# Altium schematic sheet MCU_Signals.SchDoc(MCU_Signals)
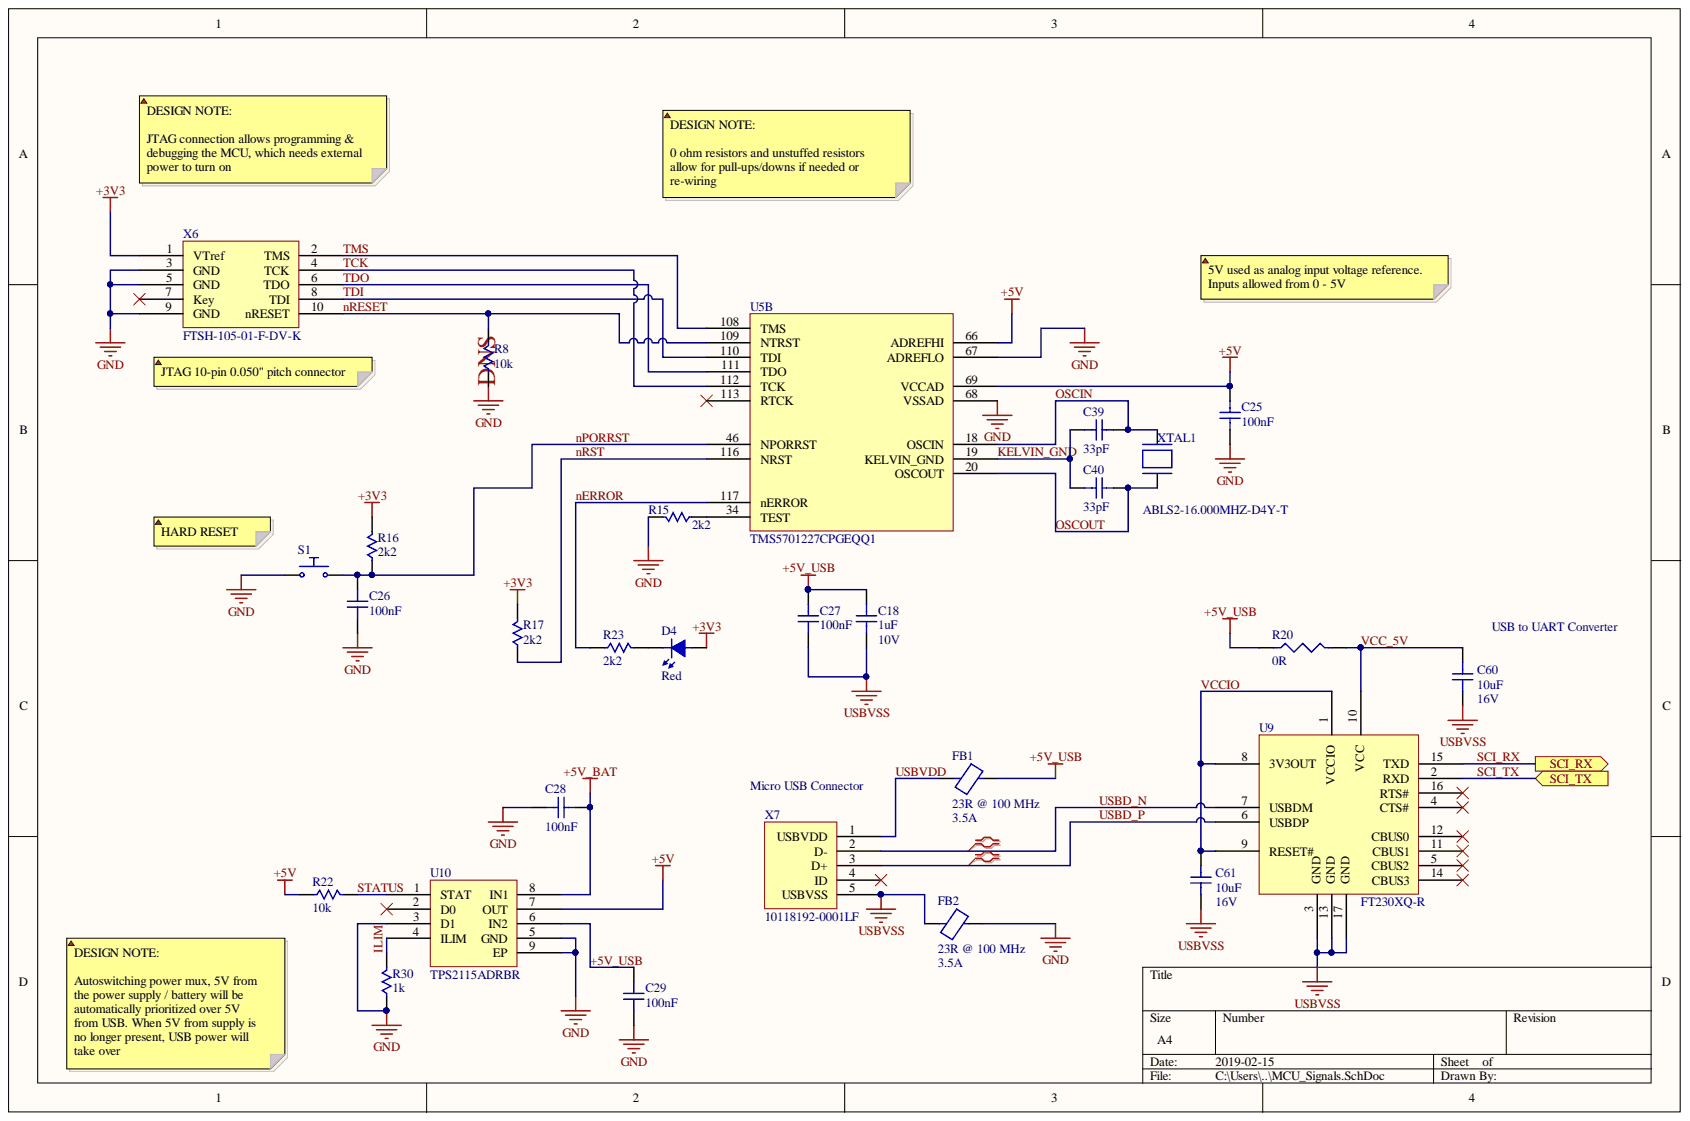
[diagram p0: MCU_Signals.SchDoc]

## Parts
C18  1uF  Voltage Rating (DC): 10V  [C0402]
C25  100nF  [C0402]
C26  100nF  [C0402]
C27  100nF  [C0402]
C28  100nF  [C0402]
C29  100nF  [C0402]
C39  33pF  [C0402]
C40  33pF  [C0402]
C60  10uF  Voltage Rating (DC): 16V  [C0805]
C61  10uF  Voltage Rating (DC): 16V  [C0805]
D4  Red  [LED_0603]
FB1  23R @ 100 MHz  Max DC Current: 3.5A  Impedance: 23R  [FB_1806]
FB2  23R @ 100 MHz  Max DC Current: 3.5A  Impedance: 23R  [FB_1806]
R8  10k  [R0402]
R15  2k2  [R0402]
R16  2k2  [R0402]
R17  2k2  [R0402]
R20  0R  [R0402]
R22  10k  [R0402]
R23  2k2  [R0402]
R30  1k  [R0402]
S1  PTS645SM43SMTR92LFS  [PUSH_BUTTON]  (value not drawn)
U5  TMS5701227CPGEQQ1  [LQFP-144]  (part B)
U9  FT230XQ-R  [QFN_EP-16]
U10  TPS2115ADRBR  [VSON-8]
X6  FTSH-105-01-F-DV-K  [JTAG_50mil_10pin]
X7  10118192-0001LF  [USB_MICRO]
XTAL1  ABLS2-16.000MHZ-D4Y-T  [XTAL]

## Ports
SCI_RX, SCI_TX

## Nets
+3V3: D4.1 R16.2 R17.2 X6.1(VTref)
+5V: C25.2 R22.1 U5.66(ADREFHI) U5.69(VCCAD) U10.7(OUT)
+5V_BAT: C28.1 U10.8(IN1)
GND: C25.1 C26.1 C28.2 C29.1 FB2.2 R8.1 R15.2 R30.1 S1.1 U5.67(ADREFLO) U5.68(VSSAD) U10.3(D1) U10.5(GND) U10.9(EP) X6.3(GND) X6.5(GND) X6.9(GND)
USBVSS: C18.1 C27.2 C60.1 C61.1 FB2.1 U9.3(GND) U9.13(GND) U9.17(GND) X7.5(USBVSS)
+5V_USB: C18.2 C27.1 C29.2 FB1.2 R20.1 U10.6(IN2)
ILIM: R30.2 U10.4(ILIM)
KELVIN_GND: C39.2 C40.2 U5.19(KELVIN_GND)
nERROR: R23.1 U5.117(nERROR)
nPORRST: C26.2 R16.1 S1.2 U5.46(NPORRST)
nRESET: R8.2 U5.109(NTRST) X6.10(nRESET)
nRST: R17.1 U5.116(NRST)
OSCIN: C39.1 U5.18(OSCIN) XTAL1.1
OSCOUT: C40.1 U5.20(OSCOUT) XTAL1.2
SCI_RX: U9.15(TXD) ; port SCI_RX
SCI_TX: U9.2(RXD) ; port SCI_TX
STATUS: R22.2 U10.1(STAT)
TCK: U5.112(TCK) X6.4(TCK)
TDI: U5.110(TDI) X6.8(TDI)
TDO: U5.111(TDO) X6.6(TDO)
TMS: U5.108(TMS) X6.2(TMS)
USBD_N: U9.7(USBDM) X7.2(D-)
USBD_P: U9.6(USBDP) X7.3(D+)
USBVDD: FB1.1 X7.1(USBVDD)
VCC_5V: C60.2 R20.2 U9.10(VCC)
VCCIO: C61.2 U9.1(VCCIO) U9.8(3V3OUT) U9.9(RESET#)
NetD4_2: D4.2 R23.2
NetR15_1: R15.1 U5.34(TEST)

Not connected: U5 113(RTCK); U9 4(CTS#) 5(CBUS2) 11(CBUS1) 12(CBUS0) 14(CBUS3) 16(RTS#); U10 2(D0); X6 7(Key); X7 4(ID)

## Library data of the parts
C18 = CL05A105MP5NNNC: CAP MLCC 1uF 10V 0402 X5R 20% SMD 0402 85C Paper T/R; Manufacturer: Samsung; Width: 0.02inch; Voltage Rating: 10V; Tolerance: 20%; Thickness: 0.022inch; Supplier Part Number 1: 1276-1448-1-ND; Supplier 1: Digi-Key; Stock 1: 100000; Radiation Hardening: No; Pricing 1: 1=0.1, 10=0.031, 100=0.014, 500=0.01, 1000=0.0079, 2500=0.0072, 5000=0.0066 (USD); Packaging: Tape and Reel; Package Quantity: 1; Mount: Surface Mount; Min Operating Temperature: -55°C; Max Operating Temperature: 85°C; Manufacturer Part Number: CL05A105MP5NNNC; Length: 1mm; Lead Free: Lead Free; Height: 0.5mm; Dielectric: X5R; Depth: 0.5mm; ComponentLink1URL: https://media.digikey.com/pdf/Data%20Sheets/Samsung%20PDFs/CL_Series_MLCC_ds.pdf; ComponentLink1Description: https://media.digikey.com/pdf/Data%20Sheets/Samsung%20PDFs/CL_Series_MLCC_ds.pdf; Component Kind: Standard; Case Code (Metric): 1005; Case Code (Imperial): 0402; Case/Package: 0402; Capacitance: 1uF; Library Name: PHANTOM_LIBRARY.SchLib
C25-C29 = CL05A104MP5NNNC: CAP MLCC 0.1uF 10V X5R 0402 20% SMD 0402 85C Paper T/R; Manufacturer: Samsung; Width: 0.02inch; Voltage Rating (DC): 10V; Voltage Rating: 10V; Tolerance: 20%; Thickness: 0.022inch; Supplier Part Number 1: 1276-1443-1-ND; Supplier 1: Digi-Key; Stock 1: 100000; Radiation Hardening: No; Pricing 1: 1=0.1, 10=0.024, 100=0.0106, 500=0.0076, 1000=0.006, 2500=0.0054, 5000=0.005 (USD); Packaging: Tape and Reel; Mount: Surface Mount; Min Operating Temperature: -55°C; Max Operating Temperature: 85°C; Manufacturer Part Number: CL05A104MP5NNNC; Length: 1mm; Lead Free: Lead Free; Height: 0.5mm; Dielectric: X5R; Depth: 0.5mm; ComponentLink1URL: https://media.digikey.com/pdf/Data%20Sheets/Samsung%20PDFs/CL_Series_MLCC_ds.pdf; ComponentLink1Description: https://media.digikey.com/pdf/Data%20Sheets/Samsung%20PDFs/CL_Series_MLCC_ds.pdf; Component Kind: Standard; Case Code (Metric): 1005; Case Code (Imperial): 0402; Case/Package: 0402; Capacitance: 100nF; Library Name: PHANTOM_LIBRARY.SchLib
C39 C40 = 885012005010: CAP MLCC 33pF 10V C0G, NP0 0402 (1005 Metric) 0.039" L x 0.020" W (1.00mm x 0.50mm); Manufacturer: Wurth Electronics; Tolerance: 5%; Width: 0.02inch; Thickness: 0.022inch; Supplier Part Number 1: 732-7428-1-ND; Supplier 1: Digi-Key; Stock 1: 4748; Pricing 1: 1=0.1, 10=0.015, 100=0.0132, 500=0.0116 (USD); Packaging: Tape and Reel; Mount: Surface Mount; Min Operating Temperature: -55°C; Max Operating Temperature: 125°C; Manufacturer Part Number: 885012005010; Length: 0.039inch; Component Kind: Standard; Case Code (Metric): 1005; Case Code (Imperial): 0402; Case/Package: 0402; Capacitance: 33pF; Library Name: PHANTOM_LIBRARY.SchLib
C60 C61 = CL21A106KOQNNNE: CAP CER 10uF 16V X5R 10% SMD 0805 85C T/R; Manufacturer: Samsung; Dielectric: X7R; Width: 0.049inch; Voltage Rating: 16V; Voltage: 16V; Tolerance: 10%; Thickness: 0.055inch; Supplier Part Number 1: 1276-1096-1-ND; Supplier 1: Digi-Key; Stock 1: 20000; Radiation Hardening: No; Pricing 1: 1=0.21, 10=0.148, 100=0.0698, 500=0.0489, 1000=0.0405 (USD); Packaging: Tape and Reel; Package Quantity: 1; Mount: Surface Mount; Min Operating Temperature: -55°C; Max Operating Temperature: 85°C; Manufacturer Part Number: CL21A106KOQNNNE; Library Name: ESC_SYMBOLS.SchLib; Length: 2mm; Lead Free: Lead Free; Height: 1.25mm; Depth: 1.25mm; ComponentLink3URL: https://datasheet.ciiva.com/15216/getdatasheetpartid-358435-15216234.pdf; ComponentLink3Description: https://datasheet.ciiva.com/15216/getdatasheetpartid-358435-15216234.pdf; ComponentLink2URL: https://datasheet.ciiva.com/16740/cl05b104kq5nnnc-16740697.pdf; ComponentLink2Description: https://datasheet.ciiva.com/16740/cl05b104kq5nnnc-16740697.pdf; ComponentLink1URL: https://datasheet.ciiva.com/25798/cl21a106koqnnne-25798133.pdf; ComponentLink1Description: https://datasheet.ciiva.com/25798/cl21a106koqnnne-25798133.pdf; Component Kind: Standard; Case Code (Metric): 2012; Case Code (Imperial): 0805; Case/Package: 0805; Capacitance: 10uF
D4 = 150060RS75000: LED RED CLEAR 0603 SMD 625 nm, 2 V, 30 mA, 250 mcd; Manufacturer: Wurth Electronics; Wavelength: 625nm; Viewing Angle: 140°; Test Current: 20mA; Luminous Intensity: 250mcd; Lens Style: Clear,Rectangular; Forward Voltage: 2V; Forward Current: 30mA; Width: 0.8mm; Supplier Part Number 1: 732-4978-1-ND; Supplier 1: Digi-Key; Stock 1: 273101; REACH SVHC: Unknown; Pricing 1: 1=0.14, 50=0.129, 100=0.11, 500=0.095 (USD); Packaging: Cut Tape; Package Quantity: 1; Mount: Surface Mount; Min Operating Temperature: -40°C; Max Operating Temperature: 85°C; Manufacturer Part Number: 150060RS75000; Length: 1.6mm; Height: 0.7mm; Dominant Wavelength: 625nm; ComponentLink2URL: http://www.produktinfo.conrad.com/datenblaetter/750000-774999/759428-da-01-en-CHIPLED_0603_W_KL_ROT_150060RS75000.pdf; ComponentLink2Description: http://www.produktinfo.conrad.com/datenblaetter/750000-774999/759428-da-01-en-CHIPLED_0603_W_KL_ROT_150060RS75000.pdf; ComponentLink1URL: https://katalog.we-online.de/led/datasheet/150060RS75000.pdf; ComponentLink1Description: https://katalog.we-online.de/led/datasheet/150060RS75000.pdf; Component Kind: Standard; Color: Red; Case Code (Metric): 1608; Case Code (Imperial): 0603; Case/Package: 0603; Library Name: PHANTOM_LIBRARY.SchLib
FB1 FB2 = FBMJ4516HL230NTV: FERRITE BEAD Ind Chip Bead Multi-Layer 23Ohm 30% 100MHz Ferrite 3.5A 1806 T/R; Manufacturer: Taiyo Yuden; Resistance: 180623R; Number of Lines: 1; DC Resistance (DCR): 14mR; Width: 1.6mm; Tolerance: 30%; Test Frequency: 100MHz; Termination: SMD/SMT; Supplier Part Number 1: 587-3857-1-ND; Supplier 1: Digi-Key; Stock 1: 1819; Pricing 1: 1=0.26, 10=0.202, 25=0.1676, 50=0.1444, 100=0.1299, 250=0.1097, 500=0.0982, 1000=0.0837 (USD); Packaging: Tape and Reel; Package Quantity: 2000; Mount: Surface Mount; Min Operating Temperature: -40°C; Max Operating Temperature: 125°C; Max Current Rating: 3.5A; Manufacturer Part Number: FBMJ4516HL230NTV; Length: 0.177inch; Height: 1.1mm; Depth: 1.6mm; Core Material: Ferrite; ComponentLink3URL: https://www.yuden.co.jp/ut/product/category/emisuppression/FBMJ4516HL230NTV_SS.pdf; ComponentLink3Description: https://www.yuden.co.jp/ut/product/category/emisuppression/FBMJ4516HL230NTV_SS.pdf; ComponentLink2URL: http://ds.yuden.co.jp/TYCOMPAS/ut/detail.do?productNo=FBMJ4516HL230NTV&fileName=FBMJ4516HL230NTV_SS&mode=specSheetDownload; ComponentLink2Description: http://ds.yuden.co.jp/TYCOMPAS/ut/detail.do?productNo=FBMJ4516HL230NTV&fileName=FBMJ4516HL230NTV_SS&mode=specSheetDownload; ComponentLink1URL: http://www.mouser.com/ds/2/396/chipbeads01_e-559265.pdf; ComponentLink1Description: http://www.mouser.com/ds/2/396/chipbeads01_e-559265.pdf; Component Kind: Standard; Case Code (Metric): 4516; Case Code (Imperial): 1806; Case/Package: 1806; Library Name: PHANTOM_LIBRARY.SchLib
R8 R22 = RC0402JR-0710KL: RES SMD 10K OHM 5% 1/16W 0402; Manufacturer: Yageo; Voltage Rating: 50V; Temperature Coefficient: 100ppm/°C; Resistance: 10kR; Power Rating: 62.5mW; Max Power Dissipation: 63mW; Width: 0.02inch; Tolerance: 5%; Termination: SMD/SMT; Supplier Part Number 1: 311-10KJRCT-ND; Supplier 1: Digi-Key; Stock 1: 47303622; REACH SVHC: Unknown; Pricing 1: 1=0.1, 10=0.018, 100=0.0073, 1000=0.0033, 2500=0.0029, 5000=0.0024 (USD); Packaging: Tape and Reel; Package Quantity: 1; Number of Terminations: 2; Min Operating Temperature: -55°C; Military Standard: Not; Max Operating Temperature: 155°C; Manufacturer Part Number: RC0402JR-0710KL; Length: 1mm; Lead Free: Lead Free; Height: 0.016inch; Features: Moisture Resistant; Depth: 0.5mm; Composition: Thick Film; Component Kind: Standard; Case Code (Metric): 1005; Case Code (Imperial): 0402; Case/Package: 0402; Library Name: PHANTOM_LIBRARY.SchLib
R15-R17 R23 = RC0402JR-072K2L: RES SMD 2.2K OHM 5% 1/16W 0402; Manufacturer: Yageo; Working Voltage: 50V; Temperature Coefficient: 100ppm/°C; Resistance: 2.2kR; Power Rating: 63mW; Width: 0.02inch; Weight: 2.3E-05oz; Voltage Rating: 50V; Tolerance: 5%; Termination: SMD/SMT; Supplier Part Number 1: 311-2.2KJRCT-ND; Supplier 1: Digi-Key; Stock 1: 7890813; REACH SVHC: Unknown; Pricing 1: 1=0.1, 10=0.018, 100=0.0073, 1000=0.0033, 2500=0.0029, 5000=0.0024 (USD); Packaging: Tape and Reel; Package Quantity: 1; Number of Terminations: 2; Min Operating Temperature: -55°C; Military Standard: Not; Max Power Dissipation: 63mW; Max Operating Temperature: 155°C; Manufacturer Part Number: RC0402JR-072K2L; Length: 1mm; Lead Free: Lead Free; Height: 0.016inch; Features: Moisture Resistant; Depth: 0.5mm; Composition: Thick Film; Component Kind: Standard; Case Code (Metric): 1005; Case Code (Imperial): 0402; Case/Package: 0402; Library Name: PHANTOM_LIBRARY.SchLib
R20 = RC0402JR-070RL: RES SMD 0 OHM 1/16W 0402; Manufacturer: Yageo; Voltage Rating: 50V; Tolerance: 5%; Temperature Coefficient: 200ppm/°C; Resistance: 0mR; Power Rating: 62.5mW; Max Power Dissipation: 63mW; Width: 0.02inch; Termination: SMD/SMT; Supplier Part Number 1: 311-0.0JRCT-ND; Supplier 1: Digi-Key; Stock 1: 44333412; REACH SVHC: Unknown; Radiation Hardening: No; Pricing 1: 1=0.1, 10=0.017, 25=0.0124, 50=0.0096, 100=0.007, 250=0.0054, 500=0.0043, 1000=0.0032, 5000=0.0023 (USD); Packaging: Tape and Reel; Package Quantity: 1; Number of Terminations: 2; Min Operating Temperature: -55°C; Military Standard: Not; Max Operating Temperature: 155°C; Manufacturer Part Number: RC0402JR-070RL; Library Name: ESC_SYMBOLS.SchLib; Length: 1mm; Lead Free: Lead Free; Height: 0.016inch; Features: Moisture Resistant; Depth: 0.5mm; Contact Plating: Matte,Tin; Composition: Thick Film; Component Kind: Standard; Case Code (Metric): 1005; Case Code (Imperial): 0402; Case/Package: 0402
R30 = RR0510P-102-D: RES SMD 1K OHM 0.5% 1/16W 0402; Manufacturer: Susumu; Voltage Rating (DC): 25V; Temperature Coefficient: 25ppm/°C; Resistance: 1kR; Power Rating: 63mW; Width: 0.02inch; Voltage Rating: 25V; Tolerance: 0.5%; Termination: SMD/SMT; Supplier Part Number 1: RR05P1.0KDCT-ND; Supplier 1: Digi-Key; Stock 1: 721157; REACH SVHC: Unknown; Pricing 1: 1=0.1, 10=0.074, 100=0.0285, 1000=0.0125, 2500=0.0108, 5000=0.0097 (USD); Packaging: Tape and Reel; Package Quantity: 1; Number of Terminations: 2; Number of Pins: 2; Min Operating Temperature: -55°C; Max Power Dissipation: 63mW; Max Operating Temperature: 125°C; Manufacturer Part Number: RR0510P-102-D; Length: 0.039inch; Lead Free: Lead Free; Height: 0.016inch; Composition: Thin Film; ComponentLink2URL: http://www.farnell.com/datasheets/1581132.pdf; ComponentLink2Description: http://www.farnell.com/datasheets/1581132.pdf; ComponentLink1URL: http://www.susumu.co.jp/common/pdf/n_catalog_partition05_en.pdf; ComponentLink1Description: http://www.susumu.co.jp/common/pdf/n_catalog_partition05_en.pdf; Component Kind: Standard; Case Code (Metric): 1005; Case Code (Imperial): 0402; Case/Package: 0402; Library Name: PHANTOM_LIBRARY.SchLib
S1 = PTS645SM43SMTR92LFS: SWITCH TACTILE SPST-NO 0.05A 12V; Manufacturer: ITT C&K; Throw Configuration: SPST; Switch Function: Off-Mom; Operating Force: 160gf; Mechanical Life: 100000Cycles; Max Current Rating: 50mA; Illumination Type: Non-Illuminated,Illuminated; Contact Resistance: 100mR; Actuator Material: Nylon,Polyamide; Actuator Length: 0.85mm; Voltage Rating (DC): 12V; Termination: Gull Wing; Supplier Part Number 1: CKN9112CT-ND; Supplier 1: Digi-Key; Stock 1: 188827; REACH SVHC: No SVHC; Pricing 1: 1=0.16, 10=0.157, 25=0.1516, 50=0.1462, 100=0.1381, 250=0.13, 500=0.1245 (USD); Packaging: Tape and Reel; Package Quantity: 1000; Orientation: Straight; Mount: Surface Mount; Min Operating Temperature: -20°C; Max Voltage Rating (DC): 12V; Max Operating Temperature: 60°C; Manufacturer Part Number: PTS645SM43SMTR92LFS; Length: 6mm; Lead Free: Lead Free; Depth: 9mm; Current Rating: 50mA; Contact Plating: Silver; Contact Material: Silver; ComponentLink5URL: https://datasheet.ciiva.com/29931/getdatasheetpartid-764615-29931183.pdf; ComponentLink5Description: https://datasheet.ciiva.com/29931/getdatasheetpartid-764615-29931183.pdf; ComponentLink4URL: https://datasheet.ciiva.com/14920/pts645-14920735.pdf; ComponentLink4Description: https://datasheet.ciiva.com/14920/pts645-14920735.pdf; ComponentLink3URL: https://datasheet.ciiva.com/14920/pts645-14920734.pdf; ComponentLink3Description: https://datasheet.ciiva.com/14920/pts645-14920734.pdf; ComponentLink2URL: https://datasheet.ciiva.com/14920/pts645-14920733.pdf; ComponentLink2Description: https://datasheet.ciiva.com/14920/pts645-14920733.pdf; ComponentLink1URL: https://datasheet.ciiva.com/29903/getdatasheetpartid-786341-29903846.pdf; ComponentLink1Description: https://datasheet.ciiva.com/29903/getdatasheetpartid-786341-29903846.pdf; Component Kind: Standard; Colour: Black; Case/Package: SMD/SMT; Library Name: PHANTOM_LIBRARY.SchLib
U5 = TMS5701227CPGEQQ1: IC MCU 16-Bit/32-Bit Hercules TMS570 ARM Cortex R4F RISC 1280KB Flash 1.2V/3.3V 144-Pin LQFP; Manufacturer: Texas Instruments; Watchdog Timer: Yes; RAM Size: 192KB; Peripherals: DMA,POR,PWM,WDT; Oscillator Type: External; Number of Timers/Counters: 2; Number of Programmable I/O: 58; Memory Size: 1.25MB; Max Frequency: 160MHz; Interface: CAN,EBI/EMI,Ethernet,I2C,LIN,SCI,SPI,UART,USART; Density: 1310720B; Supplier Part Number 1: 296-42451-ND; Supplier 1: Digi-Key; Stock 1: 2872; Pricing 1: 1=24.99, 10=23.046, 60=22.0098, 120=19.6794, 540=17.8669 (USD); Packaging: Tray; Number of Pins: 144; Number of I/Os: 58; Number of GPIO: 58; Min Operating Temperature: -40°C; Memory Type: FLASH; Max Operating Temperature: 125°C; Manufacturer Part Number: TMS5701227CPGEQQ1; Lead Free: Lead Free; Frequency: 160MHz; Core Architecture: ARM; Component Kind: Standard; Case/Package: LQFP; Library Name: PHANTOM_LIBRARY.SchLib
U9 = FT230XQ-R: IC USB SERIAL BASIC UART 16QFN; Manufacturer: FTDI; Operating Supply Voltage: 5V; Operating Supply Current: 8mA; Number of Channels: 1; Min Supply Voltage: 2.97V; Max Supply Voltage: 5.5V; Interface: USB,UART; Data Rate: 12Mbps; Width: 4mm; Weight: 0.002014oz; Supplier Part Number 1: 768-1130-1-ND; Supplier 1: Digi-Key; Stock 1: 185744; Pricing 1: 1=2.04, 25=1.97, 100=1.9, 250=1.71, 500=1.54, 1000=1.4806 (USD); Packaging: Tape and Reel; Package Quantity: 5000; Number of Pins: 16; Mount: Surface Mount; Min Operating Temperature: -40°C; Max Operating Temperature: 85°C; Manufacturer Part Number: FT230XQ-R; Library Name: ESC_SYMBOLS.SchLib; Length: 4mm; Lead Free: Lead Free; Height: 0.75mm; ComponentLink6URL: https://datasheet.ciiva.com/30478/0900766b811020cb-30478159.pdf; ComponentLink6Description: https://datasheet.ciiva.com/30478/0900766b811020cb-30478159.pdf; ComponentLink5URL: https://datasheet.ciiva.com/1787/ft-x-series-1787311.pdf; ComponentLink5Description: https://datasheet.ciiva.com/1787/ft-x-series-1787311.pdf; ComponentLink4URL: https://datasheet.ciiva.com/1787/ft-x-series-1787310.pdf; ComponentLink4Description: https://datasheet.ciiva.com/1787/ft-x-series-1787310.pdf; ComponentLink3URL: https://datasheet.ciiva.com/1787/ft-x-series-1787309.pdf; ComponentLink3Description: https://datasheet.ciiva.com/1787/ft-x-series-1787309.pdf; ComponentLink2URL: https://datasheet.ciiva.com/48909/70403914-48909084.pdf; ComponentLink2Description: https://datasheet.ciiva.com/48909/70403914-48909084.pdf; ComponentLink1URL: https://datasheet.ciiva.com/16213/ds-ft230x-16213755.pdf; ComponentLink1Description: https://datasheet.ciiva.com/16213/ds-ft230x-16213755.pdf; Component Kind: Standard; Case/Package: QFN
U10 = TPS2115ADRBR: IC OR CTRLR SRC SELECT 8SON; Manufacturer: Texas Instruments; Turn-On Delay Time: 1ms; Turn-Off Delay Time: 5ms; Output Current: 1.25A; Operating Supply Voltage: 5.5V; Operating Supply Current: 55uA; On-State Resistance: 150mR; Number of Outputs: 1; Number of Inputs: 2; Min Input Voltage: 2.8V; Max Power Dissipation: 2.5W; Weight: 0.000847oz; Supplier Part Number 1: 296-23394-1-ND; Supplier 1: Digi-Key; Stock 1: 13497; REACH SVHC: No SVHC; Radiation Hardening: No; Pricing 1: 1=2.01, 10=1.801, 100=1.448, 500=1.1897, 1000=0.9857 (USD); Packaging: Tape and Reel; Package Quantity: 3000; Number of Pins: 8; Min Operating Temperature: -40°C; Max Supply Current: 55uA; Max Output Current: 1.25A; Max Operating Temperature: 85°C; Max Input Voltage: 5.5V; Manufacturer Part Number: TPS2115ADRBR; Lead Free: Lead Free; Current - Output 2: 1.25A; ComponentLink5URL: https://datasheet.ciiva.com/1960/tps2115a-1960794.pdf; ComponentLink5Description: https://datasheet.ciiva.com/1960/tps2115a-1960794.pdf; ComponentLink4URL: https://datasheet.ciiva.com/1960/tps2115a-1960793.pdf; ComponentLink4Description: https://datasheet.ciiva.com/1960/tps2115a-1960793.pdf; ComponentLink3URL: https://datasheet.ciiva.com/3232/tps2115a-3232536.pdf; ComponentLink3Description: https://datasheet.ciiva.com/3232/tps2115a-3232536.pdf; ComponentLink2URL: https://datasheet.ciiva.com/1960/tps2115a-1960792.pdf; ComponentLink2Description: https://datasheet.ciiva.com/1960/tps2115a-1960792.pdf; ComponentLink1URL: https://datasheet.ciiva.com/15109/getdatasheetpartid-221489-15109265.pdf; ComponentLink1Description: https://datasheet.ciiva.com/15109/getdatasheetpartid-221489-15109265.pdf; Component Kind: Standard; Case/Package: TSSOP; Library Name: PHANTOM_LIBRARY.SchLib
X6 = FTSH-105-01-F-DV-K: CONN_JTAG_10pin .050 Connector Dual Header 10 Position Surface Mount; Manufacturer: Samtec; Row Spacing: 0.05inch; Pitch: 1.27mm; Number of Positions: 10; Max Current Rating: 1.75A; Connector Type: Male Pin; Termination: Solder; Supplier Part Number 1: SAM8796-ND; Supplier 1: Digi-Key; Stock 1: 18199; Radiation Hardening: No; Pricing 1: 1=2.9, 10=2.784, 100=2.32, 500=1.972, 1000=1.682, 5000=1.508 (USD); Packaging: Rail/Tube; Package Quantity: 1; Orientation: Straight; Number of Rows: 2; Number of Contacts: 10; Mount: Surface Mount; Min Operating Temperature: -55°C; Max Operating Temperature: 125°C; Manufacturer Part Number: FTSH-105-01-F-DV-K; Length: 15.2mm; Lead Free: Lead Free; Housing Material: Polymer; Housing Colour: Black; Height: 3.3mm; Depth: 3.43mm; Contact Plating: Nickel,Gold; Contact Mating Length: 0.12inch; Contact Material: Bronze,Copper; ComponentLink5URL: https://datasheet.ciiva.com/15195/getdatasheetpartid-133463-15195260.pdf; ComponentLink5Description: https://datasheet.ciiva.com/15195/getdatasheetpartid-133463-15195260.pdf; ComponentLink4URL: https://datasheet.ciiva.com/16657/ftsh-mt-16657371.pdf; ComponentLink4Description: https://datasheet.ciiva.com/16657/ftsh-mt-16657371.pdf; ComponentLink3URL: https://datasheet.ciiva.com/10245/ftsh-mt-10245273.pdf; ComponentLink3Description: https://datasheet.ciiva.com/10245/ftsh-mt-10245273.pdf; ComponentLink2URL: https://datasheet.ciiva.com/738/ftsh-1xx-xx-xxx-dv-xxx-mkt-738998.pdf; ComponentLink2Description: https://datasheet.ciiva.com/738/ftsh-1xx-xx-xxx-dv-xxx-mkt-738998.pdf; ComponentLink1URL: https://datasheet.ciiva.com/19461/2046846-19461026.pdf; ComponentLink1Description: https://datasheet.ciiva.com/19461/2046846-19461026.pdf; Component Kind: Standard; Colour: Black; Library Name: PHANTOM_LIBRARY.SchLib
X7 = 10118192-0001LF: CONN_USB - micro B USB 2.0 Receptacle Connector 5 Position Surface Mount, Right Angle, Horizontal; Manufacturer: Amphenol FCI; Voltage Rating: 100V; USB Standard: USB 2.0; Pitch: 0.65mm; Number of Ports: 1; Number of Contacts: 5; Insulation Resistance: 1GR; Current Rating: 1.8A; Connector Type: USB,Receptacle; Termination: Solder; Supplier Part Number 1: 609-4613-1-ND; Supplier 1: Digi-Key; Stock 1: 197826; REACH SVHC: No SVHC; Radiation Hardening: No; Pricing 1: 1=0.46, 10=0.437, 25=0.3744, 50=0.318, 100=0.3056, 250=0.2744, 500=0.262 (USD); Packaging: Tape and Reel; Package Quantity: 1400; Orientation: Right Angle; Number of Terminals: 5; Mount: Surface Mount,Horizontal; Min Operating Temperature: -55°C; Max Voltage Rating (AC): 100V; Max Operating Temperature: 85°C; Manufacturer Part Number: 10118192-0001LF; Length: 7.86mm; Lead Free: Lead Free; Housing Material: Polymer; Gender: Receptacle; Flammability Rating: UL94 V-0; Depth: 5.57mm; Contact Plating: Nickel,Gold; Contact Material: Copper Alloy; ComponentLink3URL: https://datasheet.ciiva.com/4598/10118192ac-197249-4598796.pdf; ComponentLink3Description: https://datasheet.ciiva.com/4598/10118192ac-197249-4598796.pdf; ComponentLink2URL: https://datasheet.ciiva.com/851/10118192-851112.pdf; ComponentLink2Description: https://datasheet.ciiva.com/851/10118192-851112.pdf; ComponentLink1URL: https://datasheet.ciiva.com/26853/getdatasheetpartid-418041-26853891.pdf; ComponentLink1Description: https://datasheet.ciiva.com/26853/getdatasheetpartid-418041-26853891.pdf; Component Kind: Standard; Colour: Black; Library Name: PHANTOM_LIBRARY.SchLib
XTAL1 = ABLS2-16.000MHZ-D4Y-T: XTAL 16MHz ±30ppm Crystal 18pF 40 Ohm -40°C ~ 85°C  Surface Mount HC49/US; Manufacturer: Abracon; Series Resistance: 40R; Width: 0.185inch; Tolerance: 30ppm; Termination: SMD/SMT; Supplier Part Number 1: 535-9875-1-ND; Supplier 1: Digi-Key; Stock 1: 14083; REACH SVHC: Unknown; Pricing 1: 1=0.46, 10=0.385, 50=0.3466, 100=0.308, 500=0.2926 (USD); Packaging: Tape and Reel; Package Quantity: 1000; Mount: Surface Mount; Min Operating Temperature: -40°C; Max Operating Temperature: 85°C; Manufacturer Part Number: ABLS2-16.000MHZ-D4Y-T; Load Capacitance: 18pF; Length: 11.05mm; Lead Free: Lead Free; Height: 0.13inch; Frequency Tolerance: 30ppm; Frequency Stability: 30ppm; Frequency: 16MHz; ESR (Equivalent Series Resistance): 40R; Depth: 4.8mm; ComponentLink4URL: https://datasheet.ciiva.com/854/abls2-854399.pdf; ComponentLink4Description: https://datasheet.ciiva.com/854/abls2-854399.pdf; ComponentLink3URL: https://datasheet.ciiva.com/15116/getdatasheetpartid-719900-15116780.pdf; ComponentLink3Description: https://datasheet.ciiva.com/15116/getdatasheetpartid-719900-15116780.pdf; ComponentLink2URL: https://datasheet.ciiva.com/854/abls2-854401.pdf; ComponentLink2Description: https://datasheet.ciiva.com/854/abls2-854401.pdf; ComponentLink1URL: https://datasheet.ciiva.com/854/abls2-854400.pdf; ComponentLink1Description: https://datasheet.ciiva.com/854/abls2-854400.pdf; Component Kind: Standard; Library Name: PHANTOM_LIBRARY.SchLib
